# Altium schematic sheet di_interface.SchDoc(di_interface)
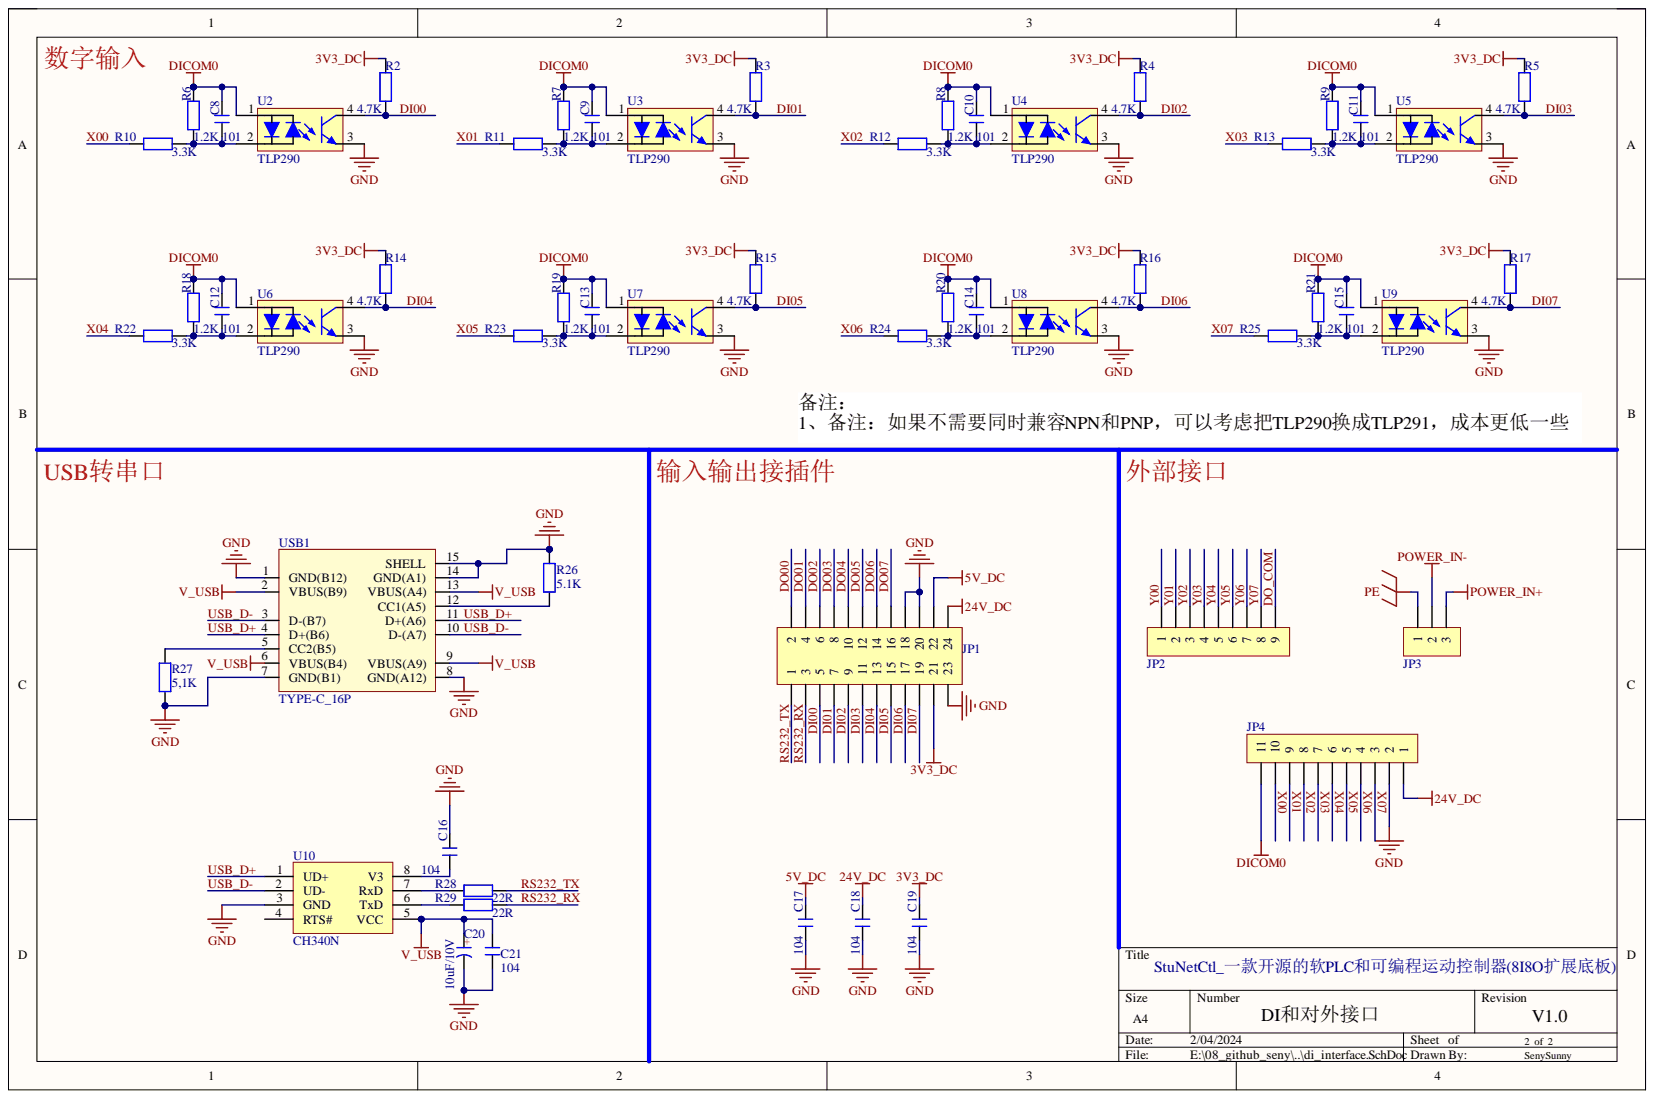
[diagram p0: di_interface.SchDoc]

## Parts
C8  101  [C 0603]
C9  101  [C 0603]
C10  101  [C 0603]
C11  101  [C 0603]
C12  101  [C 0603]
C13  101  [C 0603]
C14  101  [C 0603]
C15  101  [C 0603]
C16  104  [C 0603]
C17  104  [C 0603]
C18  104  [C 0603]
C19  104  [C 0603]
C20  10uF/10V  [CD A(3216)]
C21  104  [C 0603]
JP1  Header 2X11  [HDR-12X2]  (value not drawn)
JP2  HDR-1X9  [2EDGKG-5.08_9P]  (value not drawn)
JP3  HDR-1X3  [2EDGKG-5.08_3P]  (value not drawn)
JP4  HDR-1X11  [2EDGKG-5.08_11P]  (value not drawn)
R2  4.7K  [R 0603]
R3  4.7K  [R 0603]
R4  4.7K  [R 0603]
R5  4.7K  [R 0603]
R6  1.2K  [R 0603]
R7  1.2K  [R 0603]
R8  1.2K  [R 0603]
R9  1.2K  [R 0603]
R10  3.3K  [R 0603]
R11  3.3K  [R 0603]
R12  3.3K  [R 0603]
R13  3.3K  [R 0603]
R14  4.7K  [R 0603]
R15  4.7K  [R 0603]
R16  4.7K  [R 0603]
R17  4.7K  [R 0603]
R18  1.2K  [R 0603]
R19  1.2K  [R 0603]
R20  1.2K  [R 0603]
R21  1.2K  [R 0603]
R22  3.3K  [R 0603]
R23  3.3K  [R 0603]
R24  3.3K  [R 0603]
R25  3.3K  [R 0603]
R26  5.1K  [R 0603]
R27  5,1K  [R 0603]
R28  22R  [R 0603]
R29  22R  [R 0603]
U2  TLP290  [SOP4_L_P1.27]
U3  TLP290  [SOP4_L_P1.27]
U4  TLP290  [SOP4_L_P1.27]
U5  TLP290  [SOP4_L_P1.27]
U6  TLP290  [SOP4_L_P1.27]
U7  TLP290  [SOP4_L_P1.27]
U8  TLP290  [SOP4_L_P1.27]
U9  TLP290  [SOP4_L_P1.27]
U10  CH340N  [SOP8_N]
USB1  TYPE-C_16P  [USB-C/S_14P2]

## Nets
3V3_DC: C19.1 JP1.21 R2.1 R3.1 R4.1 R5.1 R14.1 R15.1 R16.1 R17.1
5V_DC: C17.1 JP1.22
24V_DC: C18.1 JP1.24 JP4.1
DICOM0: C8.2 C9.2 C10.2 C11.2 C12.2 C13.2 C14.2 C15.2 JP4.11 R6.1 R7.1 R8.1 R9.1 R18.1 R19.1 R20.1 R21.1 U2.1 U3.1 U4.1 U5.1 U6.1 U7.1 U8.1 U9.1
GND: C16.1 C17.2 C18.2 C19.2 C20.2 C21.2 JP1.18 JP1.20 JP1.23 JP4.2 R26.1 R27.1 U2.3 U3.3 U4.3 U5.3 U6.3 U7.3 U8.3 U9.3 U10.3(GND) USB1.1(GND(B12)) USB1.7(GND(B1)) USB1.8(GND(A12)) USB1.14(GND(A1)) USB1.15(SHELL)
PE: JP3.1
POWER_IN+: JP3.3
POWER_IN-: JP3.2
V_USB: C20.1 C21.1 U10.5(VCC) USB1.2(VBUS(B9)) USB1.6(VBUS(B4)) USB1.9(VBUS(A9)) USB1.13(VBUS(A4))
DI00: JP1.5 R2.2 U2.4
DI01: JP1.7 R3.2 U3.4
DI02: JP1.9 R4.2 U4.4
DI03: JP1.11 R5.2 U5.4
DI04: JP1.13 R14.2 U6.4
DI05: JP1.15 R15.2 U7.4
DI06: JP1.17 R16.2 U8.4
DI07: JP1.19 R17.2 U9.4
DO00: JP1.2
DO01: JP1.4
DO02: JP1.6
DO03: JP1.8
DO04: JP1.10
DO05: JP1.12
DO06: JP1.14
DO07: JP1.16
DO_COM: JP2.9
RS232_RX: JP1.3 R29.1
RS232_TX: JP1.1 R28.1
USB_D+: U10.1(UD+) USB1.4(D+(B6)) USB1.11(D+(A6))
USB_D-: U10.2(UD-) USB1.3(D-(B7)) USB1.10(D-(A7))
X00: JP4.10 R10.2
X01: JP4.9 R11.2
X02: JP4.8 R12.2
X03: JP4.7 R13.2
X04: JP4.6 R22.2
X05: JP4.5 R23.2
X06: JP4.4 R24.2
X07: JP4.3 R25.2
Y00: JP2.1
Y01: JP2.2
Y02: JP2.3
Y03: JP2.4
Y04: JP2.5
Y05: JP2.6
Y06: JP2.7
Y07: JP2.8
NetC8_1: C8.1 R6.2 R10.1 U2.2
NetC9_1: C9.1 R7.2 R11.1 U3.2
NetC10_1: C10.1 R8.2 R12.1 U4.2
NetC11_1: C11.1 R9.2 R13.1 U5.2
NetC12_1: C12.1 R18.2 R22.1 U6.2
NetC13_1: C13.1 R19.2 R23.1 U7.2
NetC14_1: C14.1 R20.2 R24.1 U8.2
NetC15_1: C15.1 R21.2 R25.1 U9.2
NetC16_2: C16.2 U10.8(V3)
NetR26_2: R26.2 USB1.12(CC1(A5))
NetR27_2: R27.2 USB1.5(CC2(B5))
NetR28_2: R28.2 U10.7(RxD)
NetR29_2: R29.2 U10.6(TxD)

Not connected: U10 4(RTS#)

## Library data of the parts
C8-C19 C21 = C: 无极性贴片电容; 版权归属: 源创客; BOM名称: 无极性贴片电容; Component Kind: Standard; 学习资源: www.ooocke.com; Library Name: zblib_v1.IntLib
C20 = C-CD: 贴片钽电容; 版权归属: 源创客; BOM名称: 贴片钽电容; Component Kind: Standard; 学习资源: www.ooocke.com; Library Name: zblib_v1.IntLib
JP1 = Header 12X2: 12*2P接插件; 版权归属: 源创客; BOM名称: 12*2P接插件; Component Kind: Standard; 学习资源: www.ooocke.com; Library Name: zblib_v1.IntLib
JP2 = Header 9: 9P接插件; 版权归属: 源创客; BOM名称: 9P接插件; Component Kind: Standard; 学习资源: www.ooocke.com; Library Name: zblib_v1.IntLib
JP3 = Header 3: 3P接插件; 版权归属: 源创客; BOM名称: 3P接插件; Component Kind: Standard; 学习资源: www.ooocke.com; Library Name: zblib_v1.IntLib
JP4 = Header 11: 11P接插件; 版权归属: 源创客; BOM名称: 11P接插件; Component Kind: Standard; 学习资源: www.ooocke.com; Library Name: zblib_v1.IntLib
R2-R29 = R: 贴片电阻; 版权归属: 源创客; BOM名称: 贴片电阻; Component Kind: Standard; 学习资源: www.ooocke.com; Library Name: zblib_v1.IntLib
U2-U9 = TLP290: 双向光耦，小体积，间距50mil; Component Kind: Standard; Library Name: zblib_v1.IntLib
U10 = CH340N: 串口转USB; 版权归属: 源创客; BOM名称: 串口转USB; Component Kind: Standard; 学习资源: www.ooocke.com; Library Name: zblib_v1.IntLib
USB1 = USB-TYPE-C-14P: USB C接口，17P,侧立; Component Kind: Standard; Library Name: zblib_v1.IntLib
